# Altium schematic sheet BV100011_ControlTopLevel.SchDoc
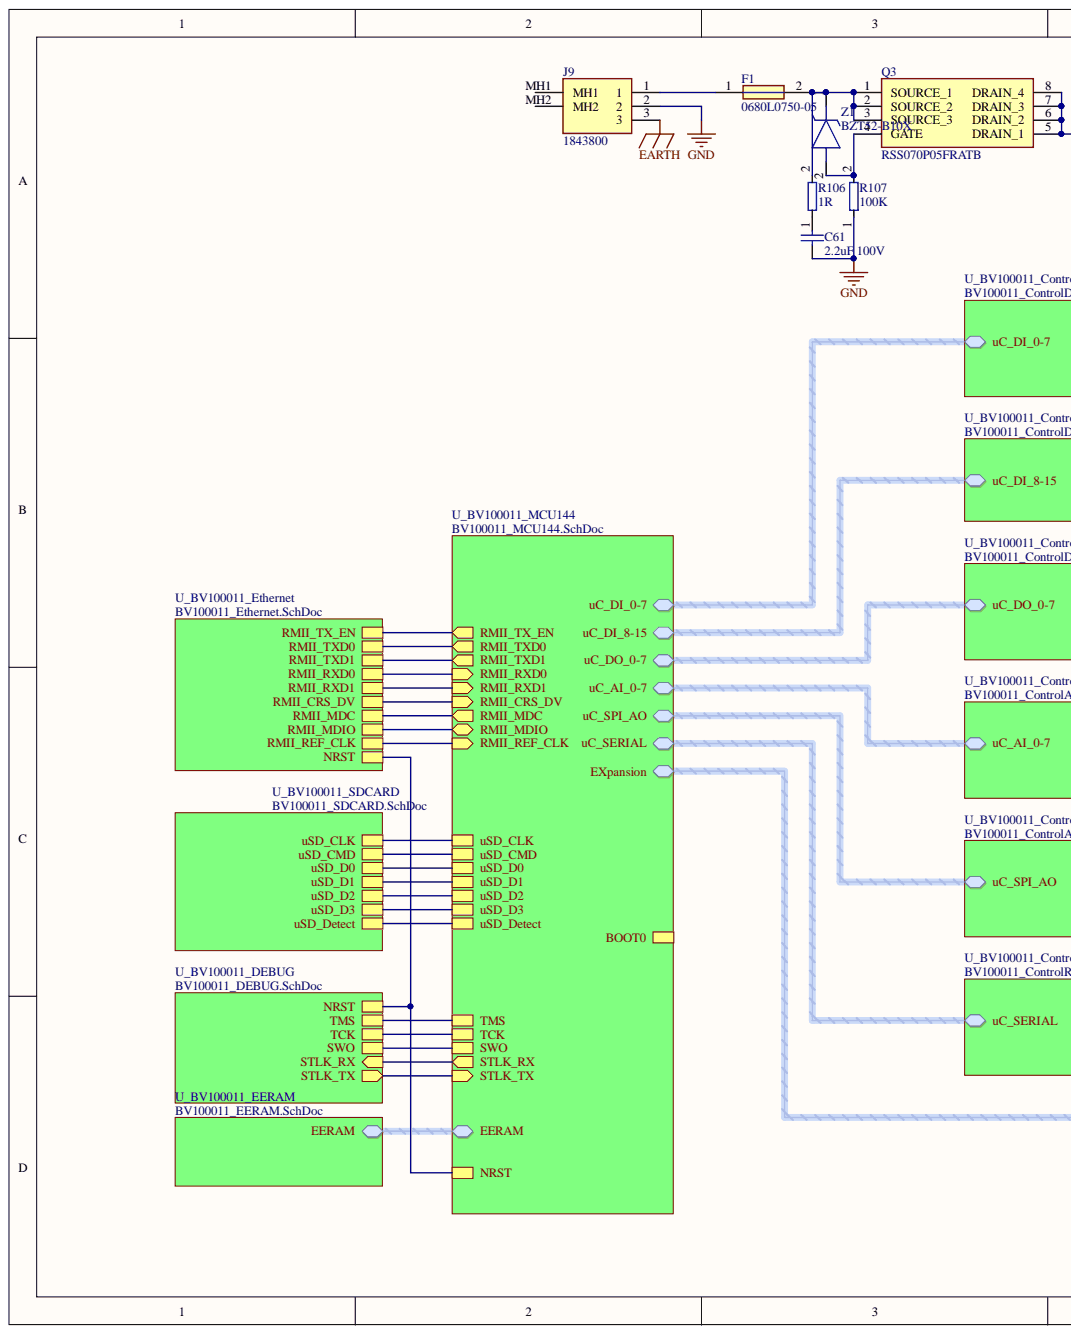
[diagram p0: BV100011_ControlTopLevel.SchDoc, left]
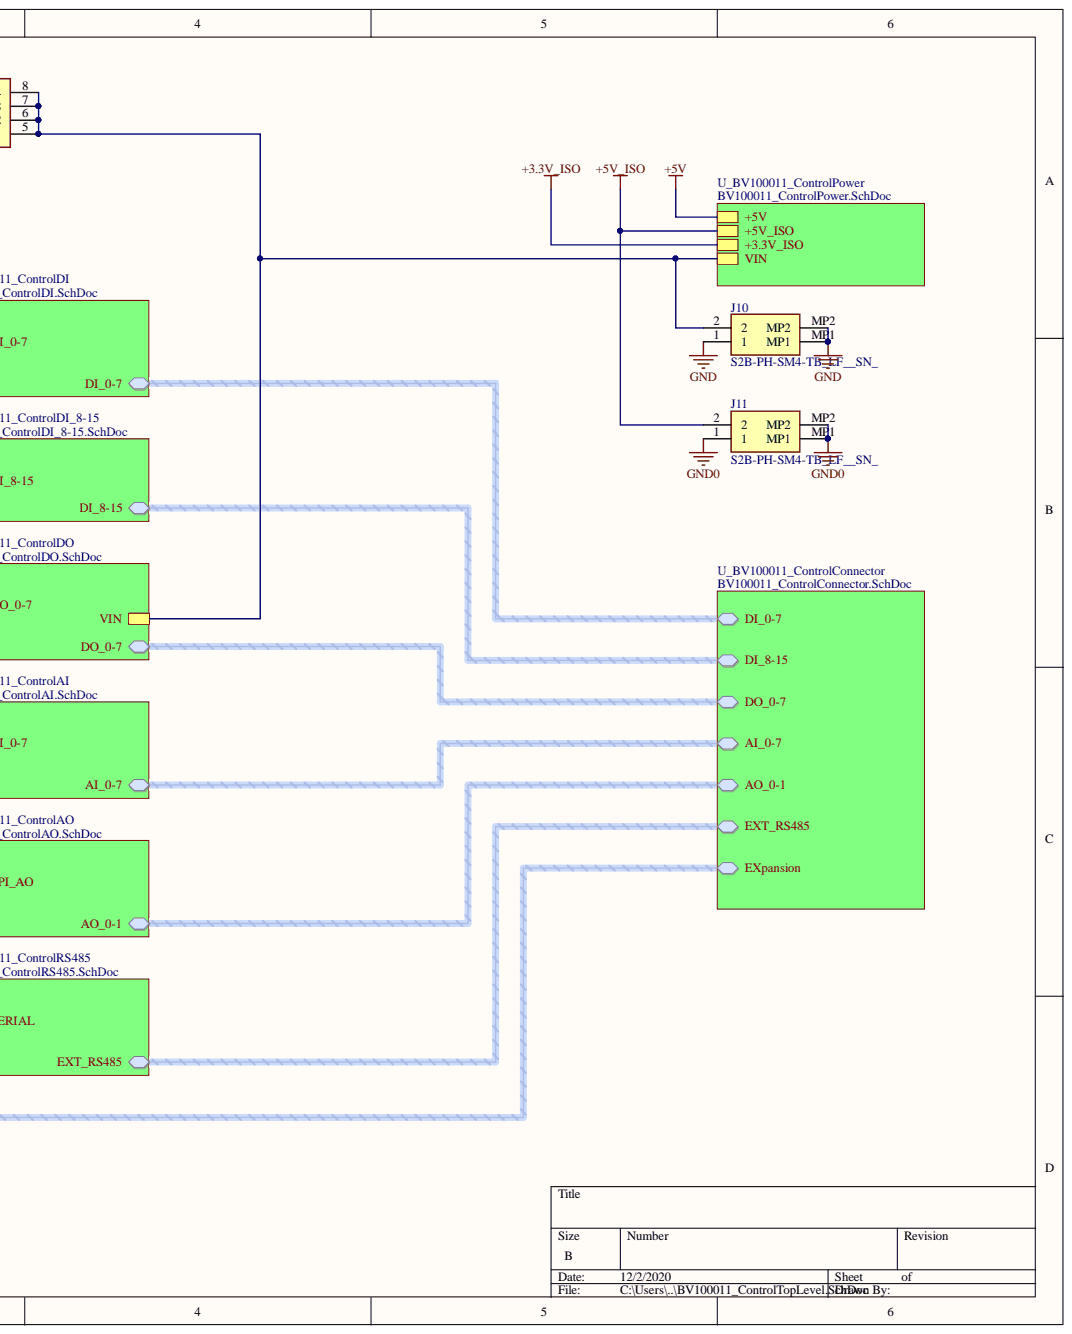
[diagram p1: BV100011_ControlTopLevel.SchDoc, right]

## Parts
C61  2.2uF 100V  [C1210]
F1  0680L0750-05  [FUSC6125X279N]
J9  1843800  [1843800]
J10  S2B-PH-SM4-TB_LF__SN_  [S2BPHSM4TBLFSN]
J11  S2B-PH-SM4-TB_LF__SN_  [S2BPHSM4TBLFSN]
Q3  RSS070P05FRATB  [SOIC127P600X175-8N]
R106  1R  [R0805]
R107  100K  [R0603]
Z1  BZT52-B10X  [SODFL3616X130N]

## Nets
+5V_ISO: J11.2
EARTH: J9.3
GND: C61.1 J9.2 J10.1 J10.MP1 J10.MP2 R107.1
GND0: J11.1 J11.MP1 J11.MP2
NetC61_2: C61.2 R106.1
NetF1_1: F1.1 J9.1
NetF1_2: F1.2 Q3.1(SOURCE_1) Q3.2(SOURCE_2) Q3.3(SOURCE_3) R106.2 Z1.1
NetJ9_MH1: J9.MH1
NetJ9_MH2: J9.MH2
NetJ10_2: J10.2 Q3.5(DRAIN_1) Q3.6(DRAIN_2) Q3.7(DRAIN_3) Q3.8(DRAIN_4)
NetQ3_4: Q3.4(GATE) R107.2 Z1.2
+3.3V_ISO:
+5V:
AI_0-7.AI0:
AI_0-7.AI1:
AI_0-7.AI2:
AI_0-7.AI3:
AI_0-7.AI4:
AI_0-7.AI5:
AI_0-7.AI6:
AI_0-7.AI7:
AO_0-1.AO_0_LOOP1:
AO_0-1.AO_0_LOOP2:
AO_0-1.AO_1_LOOP1:
AO_0-1.AO_1_LOOP2:
BOOT0:
DI_0-7.DI0:
DI_0-7.DI1:
DI_0-7.DI2:
DI_0-7.DI3:
DI_0-7.DI4:
DI_0-7.DI5:
DI_0-7.DI6:
DI_0-7.DI7:
DI_8-15.DI8:
DI_8-15.DI9:
DI_8-15.DI10:
DI_8-15.DI11:
DI_8-15.DI12:
DI_8-15.DI13:
DI_8-15.DI14:
DI_8-15.DI15:
DO_0-7.DO_0:
DO_0-7.DO_1:
DO_0-7.DO_2:
DO_0-7.DO_3:
DO_0-7.DO_4:
DO_0-7.DO_5:
DO_0-7.DO_6:
DO_0-7.DO_7:
EERAM.HS:
EERAM.I2C4_SCL:
EERAM.I2C4_SDA:
EXpansion.I2C1_SCL:
EXpansion.I2C1_SDA:
EXpansion.USART1_RX:
EXpansion.USART1_TX:
EXT_RS485.A1:
EXT_RS485.B1:
NRST:
RMII_CRS_DV:
RMII_MDC:
RMII_MDIO:
RMII_REF_CLK:
RMII_RXD0:
RMII_RXD1:
RMII_TX_EN:
RMII_TXD0:
RMII_TXD1:
STLK_RX:
STLK_TX:
SWO:
TCK:
TMS:
uC_AI_0-7.uC_AI0:
uC_AI_0-7.uC_AI1:
uC_AI_0-7.uC_AI2:
uC_AI_0-7.uC_AI3:
uC_AI_0-7.uC_AI4:
uC_AI_0-7.uC_AI5:
uC_AI_0-7.uC_AI6:
uC_AI_0-7.uC_AI7:
uC_AI_0-7.V/I_0:
uC_AI_0-7.V/I_1:
uC_DI_0-7.uC_DI0:
uC_DI_0-7.uC_DI1:
uC_DI_0-7.uC_DI2:
uC_DI_0-7.uC_DI3:
uC_DI_0-7.uC_DI4:
uC_DI_0-7.uC_DI5:
uC_DI_0-7.uC_DI6:
uC_DI_0-7.uC_DI7:
uC_DI_8-15.uC_DI8:
uC_DI_8-15.uC_DI9:
uC_DI_8-15.uC_DI10:
uC_DI_8-15.uC_DI11:
uC_DI_8-15.uC_DI12:
uC_DI_8-15.uC_DI13:
uC_DI_8-15.uC_DI14:
uC_DI_8-15.uC_DI15:
uC_DO_0-7.uC_DO_0:
uC_DO_0-7.uC_DO_0-7_EN:
uC_DO_0-7.uC_DO_0-7_FAULT:
uC_DO_0-7.uC_DO_0-7_LOAD:
uC_DO_0-7.uC_DO_0-7_SYNC:
uC_DO_0-7.uC_DO_1:
uC_DO_0-7.uC_DO_2:
uC_DO_0-7.uC_DO_3:
uC_DO_0-7.uC_DO_4:
uC_DO_0-7.uC_DO_5:
uC_DO_0-7.uC_DO_6:
uC_DO_0-7.uC_DO_7:
uC_SERIAL.uC_I/O_1:
uC_SERIAL.uC_RX1:
uC_SERIAL.uC_TX1:
uC_SPI_AO.uC_CS1:
uC_SPI_AO.uC_CS2:
uC_SPI_AO.uC_MOSI:
uC_SPI_AO.uC_SCK:
uSD_CLK:
uSD_CMD:
uSD_D0:
uSD_D1:
uSD_D2:
uSD_D3:
uSD_Detect:

## Library data of the parts
C61 = Cap: Capacitor; Value: 0.01uF; Component Kind: Standard; LatestRevisionDate: 17-Jul-2002; LatestRevisionNote: Re-released for DXP Platform.; Library Name: Miscellaneous Devices.IntLib; PackageReference: RAD-0.3; Published: 8-Jun-2000; Signal Integrity: Cap; Simulation: CAP; Publisher: Altium Limited
F1 = 0680L0750-05: Fuse; Manufacturer_Name: Stewart Connector; Component Kind: Standard; Datasheet Link: https://belfuse.com/resources/datasheets/circuitprotection/ds-cp-0680l-series.pdf; Height: 2.79mm; Manufacturer_Part_Number: 0680L0750-05; Mouser Part Number: 530-0680L0750-05; Mouser Price/Stock: https://www.mouser.co.uk/ProductDetail/Bel-Fuse/0680L0750-05?qs=XeJtXLiO41Q8xKKngRilvg%3D%3D
J9 = 1843800: Connector; Manufacturer_Name: Phoenix Contact; Arrow Part Number: 1843800; Arrow Price/Stock: https://www.arrow.com/en/products/1843800/phoenix-contact; Component Kind: Standard; Datasheet Link: https://www.phoenixcontact.com/online/portal/gb?uri=pxc-oc-itemdetail:pid=1843800&library=gben&tab=1; Height: 7.4mm; Manufacturer_Part_Number: 1843800; Mouser Part Number: 651-1843800; Mouser Price/Stock: https://www.mouser.co.uk/ProductDetail/Phoenix-Contact/1843800?qs=uJX40y%252BSWqgV2WPXXVa%2FDw%3D%3D
J10 J11 = S2B-PH-SM4-TB_LF__SN_: Connector; Manufacturer_Name: JST Sales America; Manufacturer_Part_Number: S2B-PH-SM4-TB(LF)(SN); Component Kind: Standard; Datasheet Link: https://www.jst-mfg.com/product/pdf/eng/ePH.pdf; Height: 6.6mm
Q3 = RSS070P05FRATB: MOSFET (P-Channel); Manufacturer_Name: ROHM Semiconductor; Component Kind: Standard; Datasheet Link: https://d1d2qsbl8m0m72.cloudfront.net/en/products/databook/datasheet/discrete/transistor/mosfet/rss070p05fra-e.pdf; Height: 1.75mm; Manufacturer_Part_Number: RSS070P05FRATB; Mouser Part Number: 755-RSS070P05FRATB; Mouser Price/Stock: https://www.mouser.com/Search/Refine.aspx?Keyword=755-RSS070P05FRATB
R106 R107 = 电阻（1）: Resistor; Component Kind: Standard; Library Name: 要多酷有多库.SchLib
Z1 = BZT52-B10X: Zener Diode; Manufacturer_Name: Nexperia; Arrow Part Number: BZT52-B10X; Arrow Price/Stock: https://www.arrow.com/en/products/bzt52-b10x/nexperia; Component Kind: Standard; Datasheet Link: https://componentsearchengine.com/Datasheets/2/BZT52-B10X.pdf; Height: 1.3mm; Manufacturer_Part_Number: BZT52-B10X; Mouser Part Number: 771-BZT52-B10X; Mouser Price/Stock: https://www.mouser.co.uk/ProductDetail/Nexperia/BZT52-B10X?qs=W0yvOO0ixfEZrHW2hWNc1Q%3D%3D
